# Altium schematic sheet Channel.SchDoc
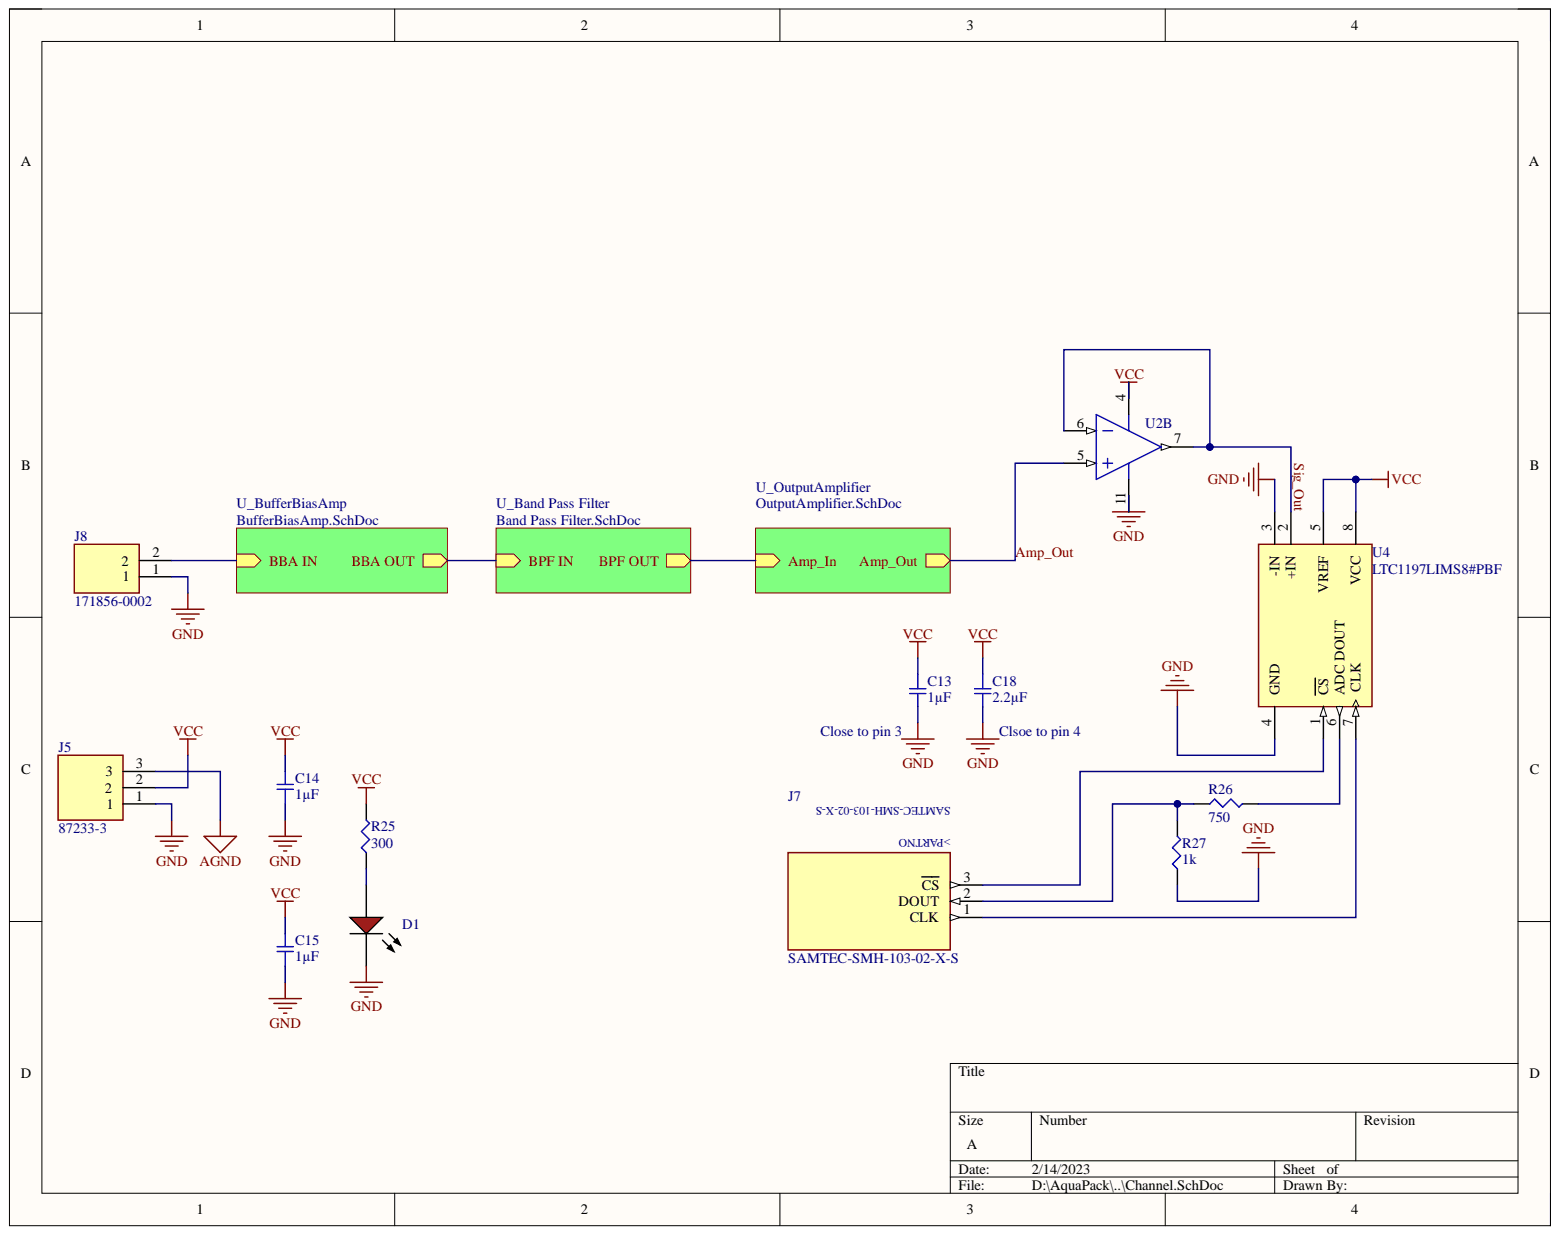
[diagram p0: Channel.SchDoc]

## Parts
C13  1µF  [SMD-0603C]
C14  1µF  [SMD-0603C]
C15  1µF  [SMD-0603C]
C18  2.2µF  [SMD-0603C]
D1  TLMS1100-GS08  [VISH-TLMX1100_V]  (value not drawn)
J5  87233-3  [TE_87233-3]
J7  SAMTEC-SMH-103-02-X-S  [SAMTEC-SMH-103-02-X-S]
J8  171856-0002  [SHDR2W99P0X254_1X2_493X635X818P]
R25  300  [SMD-0603-RES]
R26  750  [SMD-0603-RES]
R27  1k  [SMD-0603-RES]
U2  OPA1604AIPWR  [PW0014A_N]  (value not drawn)  (part B)
U4  LTC1197LIMS8#PBF  [FP-MS8-8-05-08-1660-IPC_A]

## Nets
AGND: J5.3
GND: C13.2 C14.1 C15.1 C18.2 D1.2 J5.1 J8.1 R27.1 U2.11 U4.3(-IN) U4.4(GND)
VCC: C13.1 C14.2 C15.2 C18.1 J5.2 R25.2 U2.4 U4.5(VREF) U4.8(VCC)
Amp_Out: U2.5
Sig_Out: U2.6 U2.7 U4.2(+IN)
NetD1_1: D1.1 R25.1
NetJ7_1: J7.1 U4.7(CLK)
NetJ7_2: J7.2 R26.1 R27.2
NetJ7_3: J7.3 U4.1(CS)
NetJ8_2: J8.2
NetR26_2: R26.2 U4.6(ADC_DOUT)
Amp_In:
BBA OUT:

## Library data of the parts
C13 = GRM185C80J105KE26D: CAP CER 1UF 6.3V X6S 0603; Manufacturer: Murata Electronics North America; @ qty: 0; Minimum Quantity: 1; Tolerance: ±10%; Unit Price (USD): 0.2; Applications: General Purpose; Component Kind: Standard; Datasheets: http://www.murata.com/~/media/webrenewal/support/library/catalog/products/capacitor/mlcc/c02e.pdf; Digi-Key Part Number: 490-8271-1-ND; Factory Stock: 0; Features: -; Height - Seated (Max): -; Image: //media.digikey.com/Renders/Murata%20Renders/ZRB-SERIES-0402.jpg; Lead Spacing: -; Lead Style: -; Library Name: capacitor_murata_0603.IntLib; Mounting Type: Surface Mount, MLCC; Operating Temperature: -55°C ~ 105°C; Package / Case: 0603 (1608 Metric); Packaging: Cut Tape (CT); Part Number: GRM185C80J105KE26D; Part Status: Active; Quantity Available: 11240; Ratings: -; Series: GRM; Size / Dimension: 0.063" L x 0.031" W (1.60mm x 0.80mm); Temperature Coefficient: X6S; Thickness (Max): 0.020" (0.50mm); Value: 1µF; Voltage: 6.3V
C14 C15 = GCM188R71C105KA64D: CAP CER 1UF 16V X7R 0603; Manufacturer: Samsung Electro-Mechanics; Manufacturer Part Number: CL10A105KA8NNNC; Component Kind: Standard; Datasheet Link: https://www.digikey.com/en/products/detail/samsung-electro-mechanics/CL10A105KA8NNNC/3886760; Library Name: capacitor_murata_0603.IntLib; Operating Temperature: -55°C ~ 125°C; Package / Case: 0603 (1608 Metric); Value: 1µF
C18 = GCM188R70J225KE22D: CAP CER 2.2UF 6.3V X7R 0603; Manufacturer: Murata Electronics North America; @ qty: 0; Minimum Quantity: 1; Tolerance: ±10%; Unit Price (USD): 0.23; Applications: Automotive; Component Kind: Standard; Datasheets: http://www.murata.com/~/media/webrenewal/support/library/catalog/products/capacitor/mlcc/c03e.ashx; Digi-Key Part Number: 490-5316-1-ND; Factory Stock: 0; Features: -; Height - Seated (Max): -; Image: //media.digikey.com/Renders/Murata%20Renders/0603%28GCM188%29.jpg; Lead Spacing: -; Lead Style: -; Library Name: capacitor_murata_0603.IntLib; Mounting Type: Surface Mount, MLCC; Operating Temperature: -55°C ~ 125°C; Package / Case: 0603 (1608 Metric); Packaging: Cut Tape (CT); Part Number: GCM188R70J225KE22D; Part Status: Active; Quantity Available: 20695; Ratings: AEC-Q200; Series: GCM; Size / Dimension: 0.063" L x 0.031" W (1.60mm x 0.80mm); Temperature Coefficient: X7R; Thickness (Max): 0.035" (0.90mm); Value: 2.2µF; Voltage: 6.3V
D1 = CMP-1666-00027-1: LED, RED, 2.1 V, -40 to 100 degC, 2-Pin SMD (0603), RoHS, Tape and Reel; Manufacturer: Wurth Elektronics; Manufacturer Part Number: 150080SS75000; Value: 1.9V; Component Kind: Standard; Datasheet Link: https://www.mouser.com/ProductDetail/Wurth-Elektronik/150080SS75000?qs=LlUlMxKIyB2zzpiaOzrUdw%3D%3D&mgh=1&gclid=Cj0KCQjwm6KUBhC3ARIsACIwxBhChbj4MsdASq_HKZ9IIHp_SBsI6cWeNQVHWnPWPX4ZaUjtoXFUdFUaAm30EALw_wcB; Library Name: Altium Content Vault; Operating Temperature: -40to85degC; Package / Case: 0805 (2012 Metric); RoHS: Pb-Free
J5 = 87233-3: MF: {'manufacturer': u'TE Connectivity'}; Availability: Unavailable; Component Kind: Standard; Library Name: 87233-3.SchLib; MP: 87233-3; Package: None; Price: None
J7 = SAMTEC-SMH-103-02-X-S: MF: {'manufacturer': u'Samtec'}; Availability: Unavailable; Component Kind: Standard; MP: SAMTEC-SMH-103-02-X-S; Package: None; Price: None
J8 = 171856-0002: Connector; Manufacturer_Name: Molex; Arrow Part Number: 171856-0002; Arrow Price/Stock: https://www.arrow.com/en/products/171856-0002/molex; Component Kind: Standard; Datasheet Link: https://www.digikey.com/product-detail/en/molex/1718560002/WM10153-ND/4423109; Height: 8.18mm; Manufacturer_Part_Number: 171856-0002; Mouser Part Number: 538-171856-0002; Mouser Price/Stock: https://www.mouser.co.uk/ProductDetail/Molex/171856-0002?qs=s7UCm7gO1bYKeEMrirBzyw%3D%3D
R25 = ERJ-3EKF3000V: RES SMD 300 OHM 1% 1/10W 0603; Manufacturer: Panasonic Electronic Components; Component Kind: Standard; Datasheet Link: https://www.digikey.com/en/products/detail/panasonic-electronic-components/ERJ-3EKF3000V/1746363; Library Name: Panasonic_resistor_0603.IntLib; Manufacturer Part Number: ERJ-3EKF3000V; Operating Temperature: -55°C ~ 155°C; Package / Case: 0603 (1608 Metric); Value: 300
R26 = ERJ-3EKF7500V: RES SMD 750 OHM 1% 1/10W 0603; Manufacturer: Panasonic Electronic Components; @ qty: 1500; Factory Stock: 0; Minimum Quantity: 1; Power (Watts): 0.1W, 1/10W; Temperature Coefficient: ±100ppm/°C; Unit Price (USD): 0.00379; Component Kind: Standard; Composition: Thick Film; Datasheets: http://industrial.panasonic.com/www-cgi/jvcr13pz.cgi?E+PZ+3+AOA0002+ERJ3EKF7500V+7+WW; Digi-Key Part Number: P750HCT-ND; Failure Rate: -; Features: Automotive AEC-Q200; Height - Seated (Max): 0.022" (0.55mm); Image: //media.digikey.com/Photos/Panasonic%20Photos/MFG_ERJ-P6W%20Series.jpg; Library Name: Panasonic_resistor_0603.IntLib; Number of Terminations: 2; Operating Temperature: -55°C ~ 155°C; Package / Case: 0603 (1608 Metric); Packaging: Cut Tape (CT); Part Number: ERJ-3EKF7500V; Part Status: Active; Quantity Available: 102837; Series: ERJ; Size / Dimension: 0.063" L x 0.031" W (1.60mm x 0.80mm); Supplier Device Package: 0603; Tolerance: ±1%; Value: 750
R27 = ERJ-3EKF1001V: RES SMD 1K OHM 1% 1/10W 0603; Manufacturer: Panasonic Electronic Components; @ qty: 1500; Factory Stock: 0; Minimum Quantity: 1; Power (Watts): 0.1W, 1/10W; Temperature Coefficient: ±100ppm/°C; Unit Price (USD): 0.00379; Component Kind: Standard; Composition: Thick Film; Datasheets: http://industrial.panasonic.com/www-cgi/jvcr13pz.cgi?E+PZ+3+AOA0002+ERJ3EKF1001V+7+WW; Digi-Key Part Number: P1.00KHCT-ND; Failure Rate: -; Features: Automotive AEC-Q200; Height - Seated (Max): 0.022" (0.55mm); Image: //media.digikey.com/Photos/Panasonic%20Photos/MFG_ERJ-P6W%20Series.jpg; Library Name: Panasonic_resistor_0603.IntLib; Number of Terminations: 2; Operating Temperature: -55°C ~ 155°C; Package / Case: 0603 (1608 Metric); Packaging: Cut Tape (CT); Part Number: ERJ-3EKF1001V; Part Status: Active; Quantity Available: 5218845; Series: ERJ; Size / Dimension: 0.063" L x 0.031" W (1.60mm x 0.80mm); Supplier Device Package: 0603; Tolerance: ±1%; Value: 1k
U2 = CMP-0272-01731-3: Sound Plus High-Performance, Bipolar-Input Audio Operational Amplifier, 4.5 to 36 V, -40 to 85 degC, 14-pin SOP (PW14), Green (RoHS & no Sb/Br); Manufacturer: Texas Instruments; Component Kind: Standard; Datasheet Link: https://www.digikey.com/en/products/detail/texas-instruments/OPA1604AIPWR/2793768; Library Name: Altium Content Vault; Manufacturer Part Number: OPA1604AIPWR; Operating Temperature: -40°C ~ 85°C; Package / Case: 14-TSSOP
U4 = CMP-09081-000582-1: IC ADC 10BIT SAR 8MSOP; Integral Nonlinearity (INL): 1LSB; Interface: SPI; Max Power Dissipation: 25mW; Max Supply Voltage: 4V; Min Supply Voltage: 2.7V; Number of Bits: 10; Number of Converters: 1; Operating Supply Voltage: 3V; Polarity: Unipolar; Power Dissipation: 500mW; Resolution: 10b; Sampling Rate: 250000S/s; Supply Type: Single; Case/Package: MSOP; Component Kind: Standard; Lead Free: Lead Free; Library Name: Altium Content Vault; Max Operating Temperature: 85°C; Min Operating Temperature: -40°C; Mount: Surface Mount; Number of Elements: 1; Number of Pins: 8; Package Quantity: 50; Packaging: Rail/Tube; RoHS Compliant: Yes
